# Altium schematic sheet PowerSupply.SchDoc(PowerSupply)
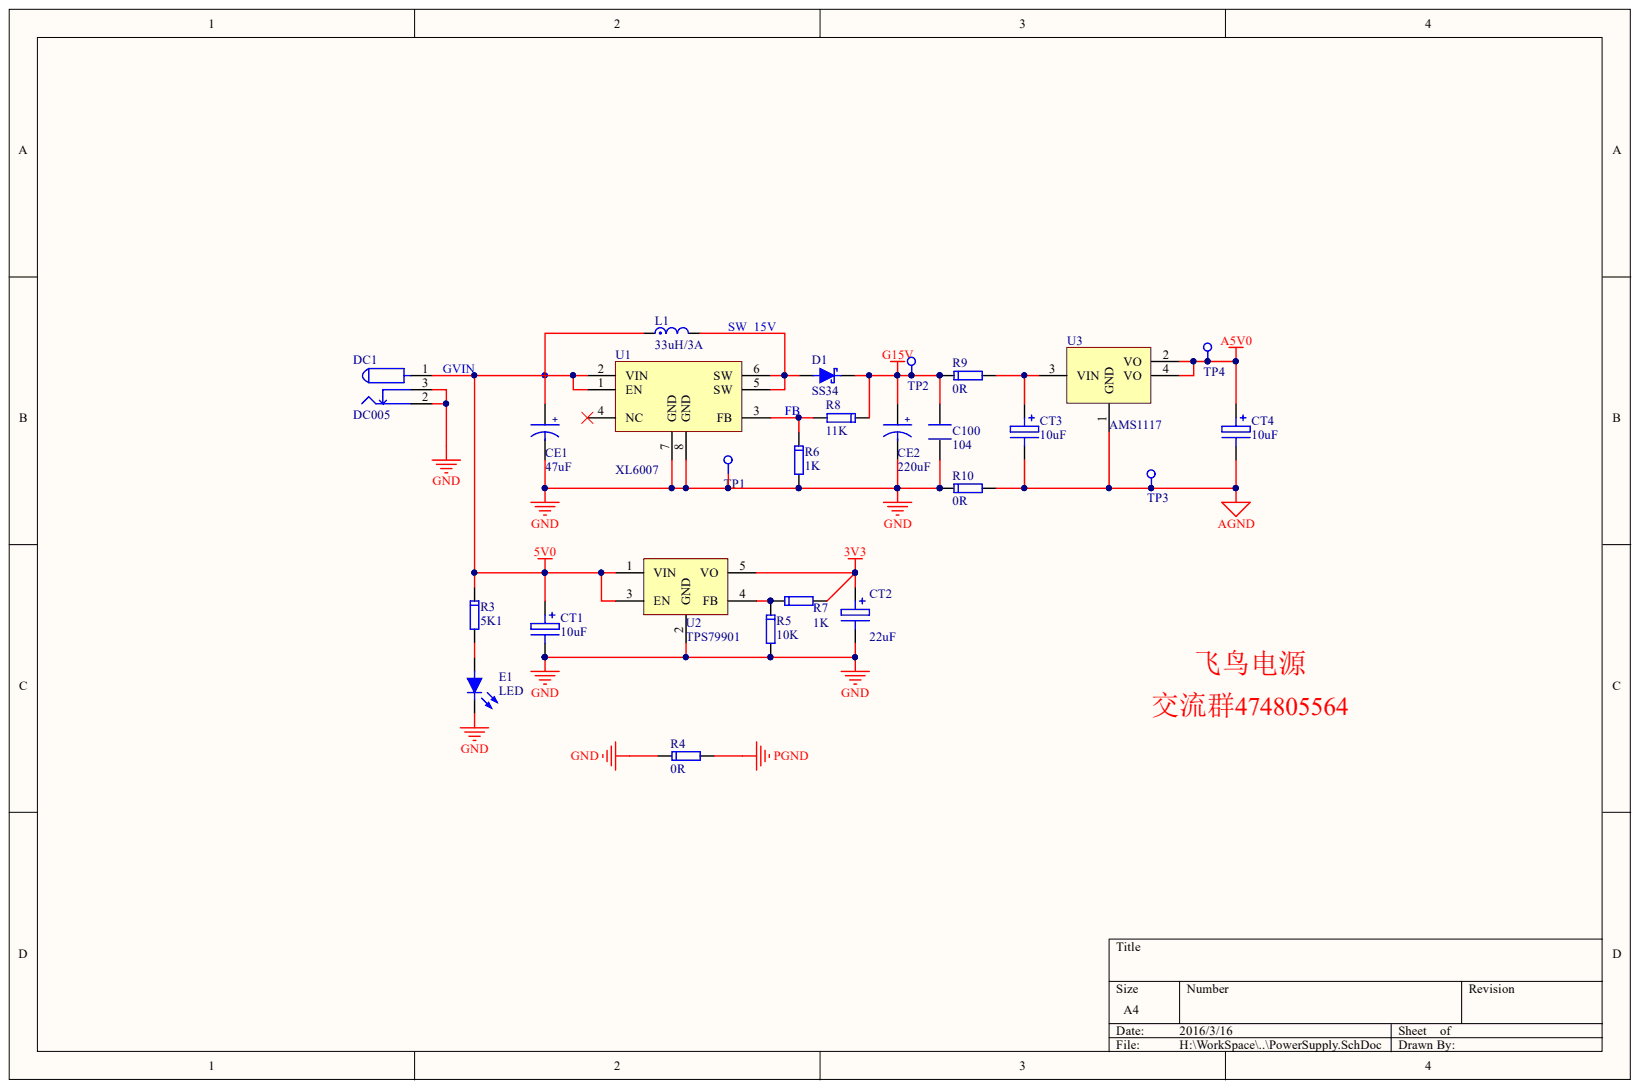
[diagram p0: PowerSupply.SchDoc]

## Parts
C100  104  [C0805]
CE1  47uF  [C1812]
CE2  220uF  [C1812]
CT1  10uF  [C0805]
CT2  22uF  [C0805]
CT3  10uF  [C0805]
CT4  10uF  [C0805]
D1  SS34  [SMA]
DC1  DC005  [DC002]
E1  LED  [D0603-R]
L1  33uH/3A  [L0630]
R3  5K1  [R0603]
R4  0R  [R1206]
R5  10K  [R0603]
R6  1K  [R0603]
R7  1K  [R0603]
R8  11K  [R0603]
R9  0R  [R0805]
R10  0R  [R0805]
TP1  5007  [Keystone5007]  (value not drawn)
TP2  5007  [Keystone5007]  (value not drawn)
TP3  5007  [Keystone5007]  (value not drawn)
TP4  5007  [Keystone5007]  (value not drawn)
U1  XL6007  [SOP8]
U2  TPS79901  [SOT23-5]
U3  AMS1117  [SOT-223]

## Nets
3V3: CT2.1 R7.2 U2.5(VO)
A5V0: CT4.1 TP4.1 U3.2(VO) U3.4(VO)
AGND: CT3.2 CT4.2 R10.2 TP3.1 U3.1(GND)
G15V: C100.2 CE2.1 D1.2 R8.1 R9.1 TP2.1
GND: C100.1 CE1.2 CE2.2 CT1.2 CT2.2 DC1.2 DC1.3 E1.K R4.1 R5.2 R6.2 R10.1 TP1.1 U1.7(GND) U1.8(GND) U2.2(GND)
PGND: R4.2
FB: R6.1 R8.2 U1.3(FB)
GVIN: CE1.1 CT1.1 DC1.1 L1.1 R3.1 U1.1(EN) U1.2(VIN) U2.1(VIN) U2.3(EN)
SW_15V: D1.1 L1.2 U1.5(SW) U1.6(SW)
NetCT3_1: CT3.1 R9.2 U3.3(VIN)
NetE1_A: E1.A R3.2
NetR5_1: R5.1 R7.1 U2.4(FB)

Not connected: U1 4(NC)

## Library data of the parts
C100 = Capacitor: Component Kind: Standard; Library Name: PP_SCHlib_Altium.SchLib
CE1 CE2 = CAP ELECTRO: Capacitor; Component Kind: Standard; Library Name: PP_SCH.SchLib
CT1-CT4 = CAP Tantalum: Component Kind: Standard; Library Name: PP_SCH.SchLib
D1 = DIODE SCHOTTKY: Component Kind: Standard; Library Name: PP_SCH.SchLib
E1 = LED: Typical RED, GREEN, YELLOW, AMBER GaAs LED; Component Kind: Standard; LatestRevisionDate: 17-Jul-2002; LatestRevisionNote: Re-released for DXP Platform.; Library Name: PP_SCH.SchLib; PackageDocument: Feb-2002; PackageReference: 3.2X1.6X1.1; Published: 8-Jun-2000; Publisher: Altium Limited
L1 = INDUTOR: Component Kind: Standard; Library Name: PP_SCH.SchLib
R3-R10 = Resistor: Component Kind: Standard; Library Name: PP_SCH.SchLib
TP1-TP4 = 5007: Test Point, Compact, White, TH; Manufacturer: Keystone; CompType: Test Point Loop; U/M: EA; Component Kind: Standard; ComponentLink1Description: Datasheet Link; ComponentLink1URL: http://www.keyelco.com/userAssets/file/M60-2p60.pdf; LatestRevisionDate: 2013-08-13; Library Name: PP_SCHlib_Altium.SchLib; MountType: TH; PackageReference: White Compact Testpoint; PartNumber: 5007; RoHS: Y; Supplier 1: Digi-Key; Supplier Part Number 1: 5007K-ND; SymbolVersion: 2008-04-04; Value: White; Publisher: Texas Instruments
U2 = TPS79901: Io = 200mA; Component Kind: Standard; Library Name: PP_SCH.SchLib
DC1 = DC005: Component Kind: Standard; Library Name: PP_SCH.SchLib
U1 = XL6007: Component Kind: Standard; Library Name: PP_SCH.SchLib
U3 = AMS1117: Component Kind: Standard; Library Name: PP_SCH.SchLib
